# Altium schematic sheet 05_User_Interface.SchDoc
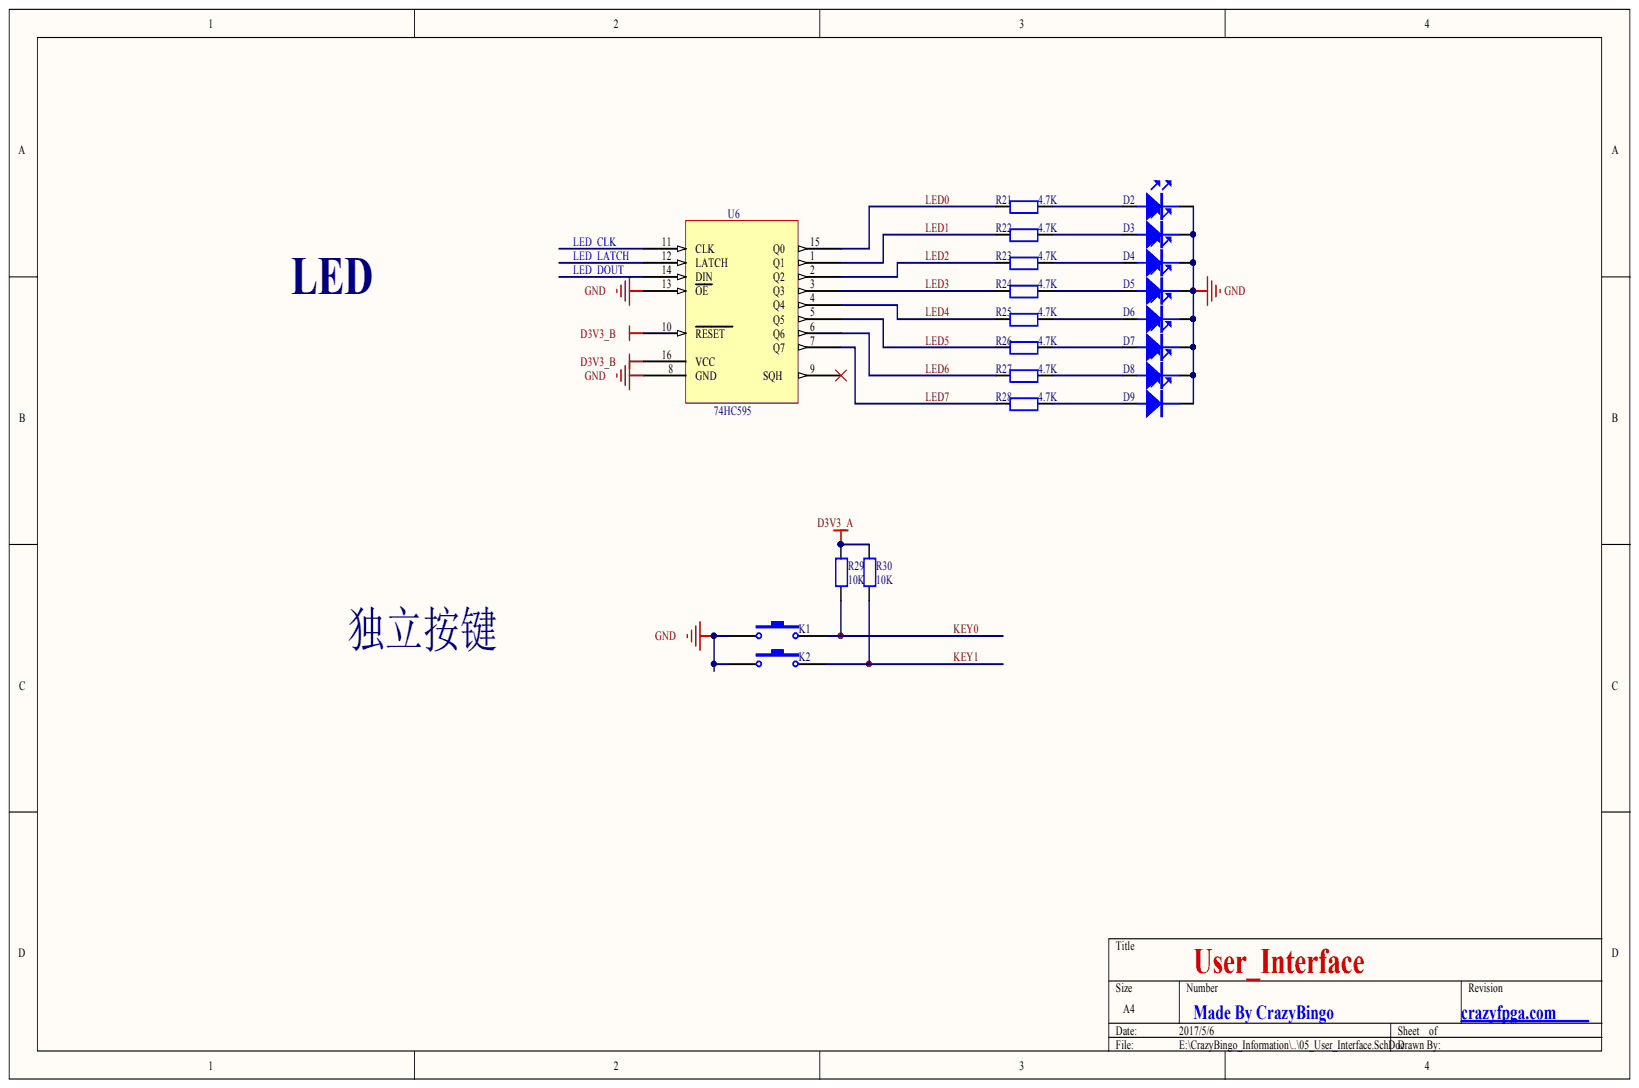
[diagram p0: 05_User_Interface.SchDoc]

## Parts
D2  LED  [D0603]  (value not drawn)
D3  LED  [D0603]  (value not drawn)
D4  LED  [D0603]  (value not drawn)
D5  LED  [D0603]  (value not drawn)
D6  LED  [D0603]  (value not drawn)
D7  LED  [D0603]  (value not drawn)
D8  LED  [D0603]  (value not drawn)
D9  LED  [D0603]  (value not drawn)
K1  SW-PB  [KEY2]  (value not drawn)
K2  SW-PB  [KEY2]  (value not drawn)
R21  4.7K  [R0603]
R22  4.7K  [R0603]
R23  4.7K  [R0603]
R24  4.7K  [R0603]
R25  4.7K  [R0603]
R26  4.7K  [R0603]
R27  4.7K  [R0603]
R28  4.7K  [R0603]
R29  10K  [R0603]
R30  10K  [R0603]
U6  74HC595  [TSSOP16]

## Nets
D3V3_A: R29.2 R30.2
D3V3_B: U6.10(RESET) U6.16(VCC)
GND: D2.2 D3.2 D4.2 D5.2 D6.2 D7.2 D8.2 D9.2 K1.1 K2.1 U6.8(GND) U6.13(OE)
KEY0: K1.2 R29.1
KEY1: K2.2 R30.1
LED0: R21.1 U6.15(Q0)
LED1: R22.1 U6.1(Q1)
LED2: R23.1 U6.2(Q2)
LED3: R24.1 U6.3(Q3)
LED4: R25.1 U6.4(Q4)
LED5: R26.1 U6.5(Q5)
LED6: R27.1 U6.6(Q6)
LED7: R28.1 U6.7(Q7)
LED_CLK: U6.11(CLK)
LED_DOUT: U6.14(DIN)
LED_LATCH: U6.12(LATCH)
NetD2_1: D2.1 R21.2
NetD3_1: D3.1 R22.2
NetD4_1: D4.1 R23.2
NetD5_1: D5.1 R24.2
NetD6_1: D6.1 R25.2
NetD7_1: D7.1 R26.2
NetD8_1: D8.1 R27.2
NetD9_1: D9.1 R28.2

Not connected: U6 9(SQH)

## Library data of the parts
D2-D9 = LED: LED 发光二极管; Component Kind: Standard; Library Name: Crazy_PCB.SchLib
K1 K2 = SW-PB: Switch; Component Kind: Standard; LatestRevisionDate: 17-Jul-2002; LatestRevisionNote: Re-released for DXP Platform.; Library Name: Miscellaneous Devices.IntLib; PackageReference: SPST-2; Published: 24-Mar-1999; Publisher: Altium Limited
R21-R30 = RES: Component Kind: Standard; Library Name: Crazy_PCB.SchLib
U6 = 74HC595: Component Kind: Standard; Library Name: Crazy_PCB.SchLib
